# Altium schematic sheet ECO_Main_boardV1.0.SchDoc
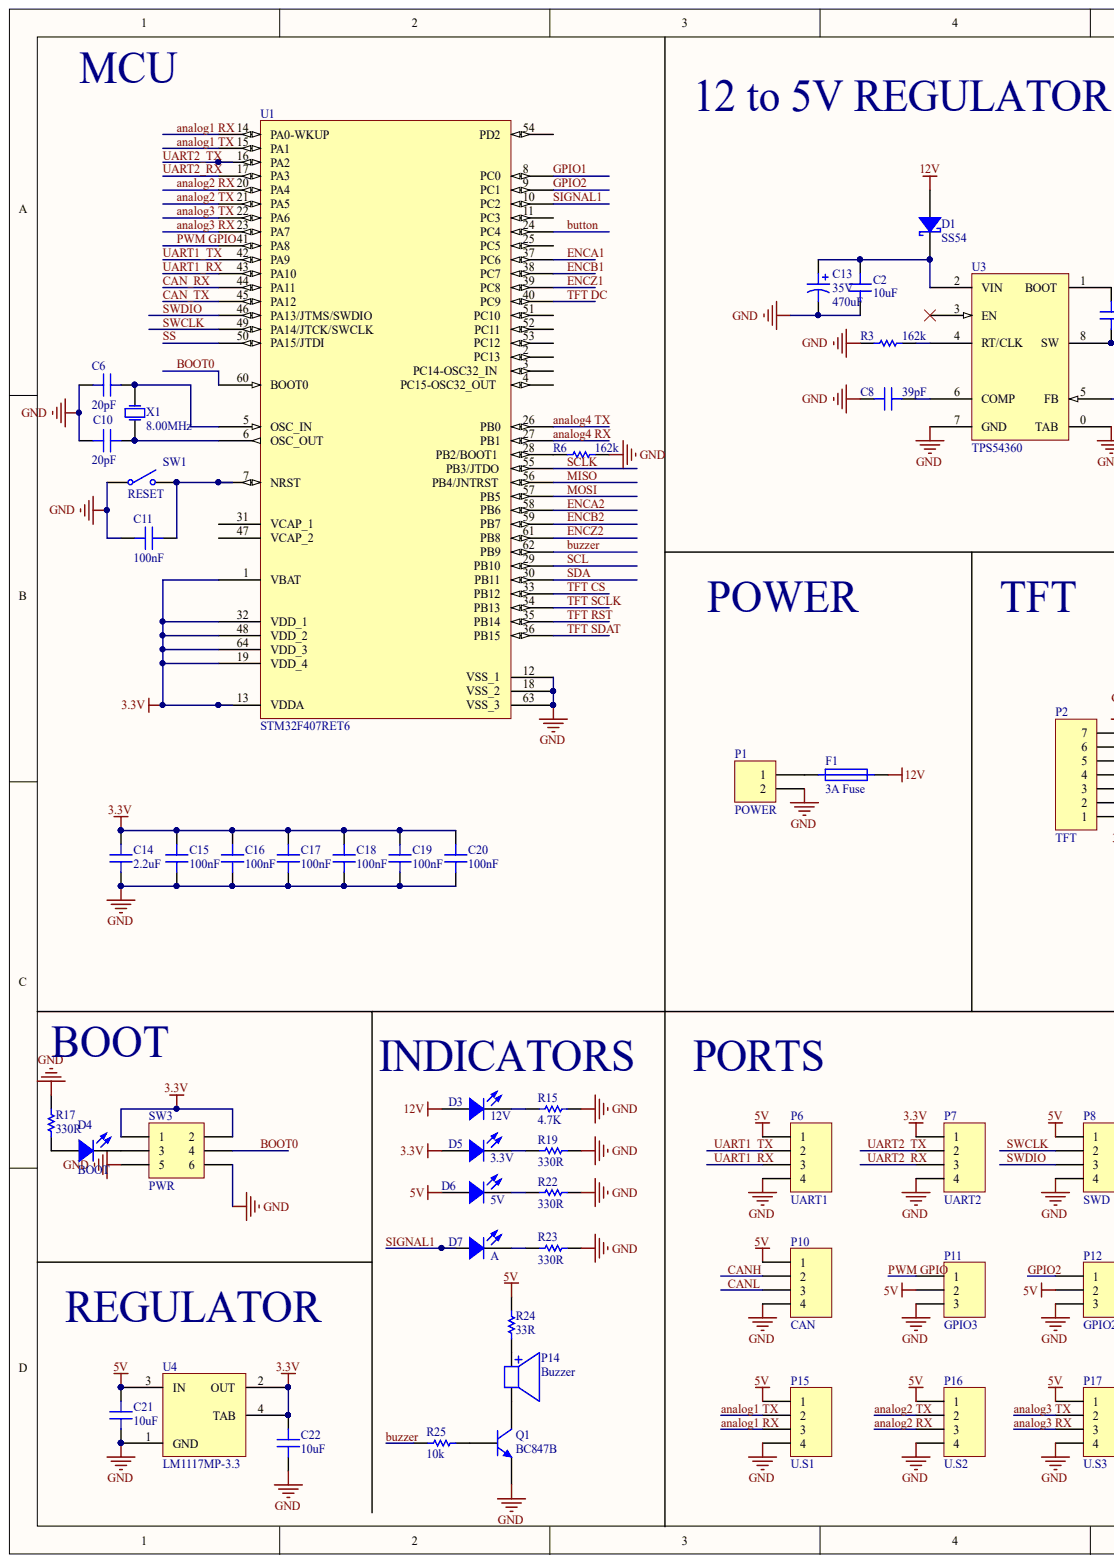
[diagram p0: ECO_Main_boardV1.0.SchDoc, left]
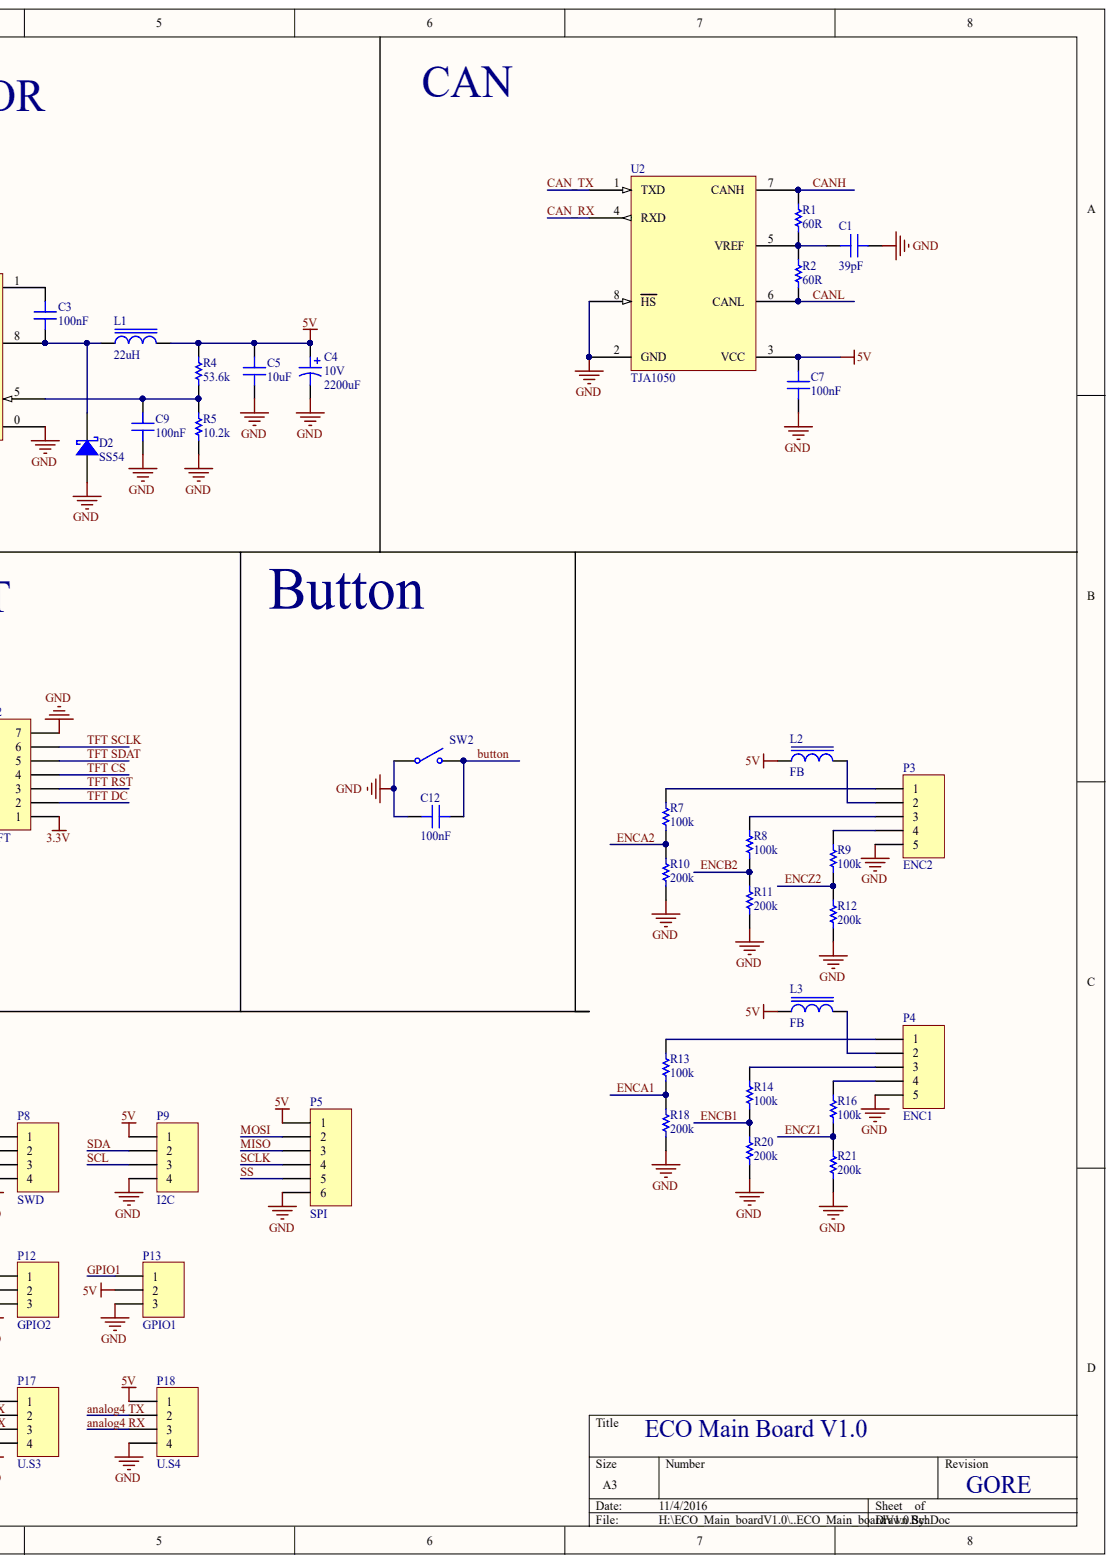
[diagram p1: ECO_Main_boardV1.0.SchDoc, right]

## Parts
C1  39pF  [CC2012-0805]
C2  10uF  [CC2012-0805]
C3  100nF  [CC2012-0805]
C4  2200uF  Voltage: 10V  [SV-G]
C5  10uF  [CC2012-0805]
C6  20pF  [CC2012-0805]
C7  100nF  [CC2012-0805]
C8  39pF  [CC2012-0805]
C9  100nF  [CC2012-0805]
C10  20pF  [CC2012-0805]
C11  100nF  [CC2012-0805]
C12  100nF  [CC2012-0805]
C13  470uF  Voltage: 35V  [CAP10x12]
C14  2.2uF  [CC2012-0805]
C15  100nF  [CC2012-0805]
C16  100nF  [CC2012-0805]
C17  100nF  [CC2012-0805]
C18  100nF  [CC2012-0805]
C19  100nF  [CC2012-0805]
C20  100nF  [CC2012-0805]
C21  10uF  [CC2012-0805]
C22  10uF  [CC2012-0805]
D1  SS54  [SMA]
D2  SS54  [SMA]
D3  12V  [D0805]
D4  BOOT  [D0805]
D5  3.3V  [D0805]
D6  5V  [D0805]
D7  A  [D0805]
F1  3A Fuse  [FUSE SOCKET]
L1  22uH  [CDRH74]
L2  FB  [CL3225-1210]
L3  FB  [CL3225-1210]
P1  POWER  [T-CONNECTOR(M)]
P2  TFT  [HDR1X7]
P3  ENC2  [lockedHDR-5]
P4  ENC1  [lockedHDR-5]
P5  SPI  [HDR1X6]
P6  UART1  [LOCKEDHDR-4]
P7  UART2  [LOCKEDHDR-4]
P8  SWD  [LOCKEDHDR-4]
P9  I2C  [LOCKEDHDR-4]
P10  CAN  [LOCKEDHDR-4]
P11  GPIO3  [LOCKEDHDR-3]
P12  GPIO2  [LOCKEDHDR-3]
P13  GPIO1  [LOCKEDHDR-3]
P14  Buzzer  [BUZ-9.0]
P15  U.S1  [LOCKEDHDR-4]
P16  U.S2  [LOCKEDHDR-4]
P17  U.S3  [LOCKEDHDR-4]
P18  U.S4  [LOCKEDHDR-4]
Q1  BC847B  [SOT-23]
R1  60R  [CR2012-0805]
R2  60R  [CR2012-0805]
R3  162k  [CR2012-0805]
R4  53.6k  [CR2012-0805]
R5  10.2k  [CR2012-0805]
R6  162k  [CR2012-0805]
R7  100k  [CR2012-0805]
R8  100k  [CR2012-0805]
R9  100k  [CR2012-0805]
R10  200k  [CR2012-0805]
R11  200k  [CR2012-0805]
R12  200k  [CR2012-0805]
R13  100k  [CR2012-0805]
R14  100k  [CR2012-0805]
R15  4.7K  [CR2012-0805]
R16  100k  [CR2012-0805]
R17  330R  [CR2012-0805]
R18  200k  [CR2012-0805]
R19  330R  [CR2012-0805]
R20  200k  [CR2012-0805]
R21  200k  [CR2012-0805]
R22  330R  [CR2012-0805]
R23  330R  [CR2012-0805]
R24  33R  [CR2012-0805]
R25  10k  [CR2012-0805]
SW1  RESET  [PUSH SWITCH]
SW2  (no value)  [PUSH SWITCH]
SW3  PWR  [POWER SWITCH]
U1  STM32F407RET6  [LQFP64_N]
U2  TJA1050  [SOP-8]
U3  TPS54360  [PDSO-8]
U4  LM1117MP-3.3  [MP04A_N]
X1  8.00MHz  [BCY-W2/E4.7]

## Nets
3.3V: C14.1 C15.2 C16.2 C17.2 C18.2 C19.2 C20.2 C22.2 D5.1 P2.1 P7.1 SW3.1 SW3.2 U1.1(VBAT) U1.13(VDDA) U1.19(VDD_4) U1.32(VDD_1) U1.48(VDD_2) U1.64(VDD_3) U4.2(OUT) U4.4(TAB)
5V: C4.1 C5.2 C7.2 C21.2 D6.1 L1.2 L2.1 L3.1 P5.1 P6.1 P8.1 P9.1 P10.1 P11.2 P12.2 P13.2 P15.1 P16.1 P17.1 P18.1 R4.2 R24.2 U2.3(VCC) U4.3(IN)
12V: D1.1 D3.1 F1.2
GND: C1.1 C2.1 C4.2 C5.1 C6.2 C7.1 C8.1 C9.1 C10.2 C11.2 C12.2 C13.2 C14.2 C15.1 C16.1 C17.1 C18.1 C19.1 C20.1 C21.1 C22.1 D2.1 P1.2 P2.7 P3.5 P4.5 P5.6 P6.4 P7.4 P8.4 P9.4 P10.4 P11.3 P12.3 P13.3 P15.4 P16.4 P17.4 P18.4 Q1.2 R3.1 R5.2 R6.2 R10.1 R11.1 R12.1 R15.2 R17.1 R18.1 R19.2 R20.1 R21.1 R22.2 R23.2 SW1.1 SW2.1 SW3.5 SW3.6 U1.12(VSS_1) U1.18(VSS_2) U1.63(VSS_3) U2.2(GND) U2.8(HS) U3.0(TAB) U3.7(GND) U4.1(GND)
ANALOG1 RX: P15.3 U1.14(PA0-WKUP)
ANALOG1 TX: P15.2 U1.15(PA1)
ANALOG2 RX: P16.3 U1.20(PA4)
ANALOG2 TX: P16.2 U1.21(PA5)
ANALOG3 RX: P17.3 U1.23(PA7)
ANALOG3 TX: P17.2 U1.22(PA6)
ANALOG4 RX: P18.3 U1.27(PB1)
ANALOG4 TX: P18.2 U1.26(PB0)
BOOT0: SW3.4 U1.60(BOOT0)
BUTTON: C12.1 SW2.2 U1.24(PC4)
BUZZER: R25.1 U1.62(PB9)
CAN_RX: U1.44(PA11) U2.4(RXD)
CAN_TX: U1.45(PA12) U2.1(TXD)
CANH: P10.2 R1.2 U2.7(CANH)
CANL: P10.3 R2.1 U2.6(CANL)
ENCA1: R13.1 R18.2 U1.37(PC6)
ENCA2: R7.1 R10.2 U1.58(PB6)
ENCB1: R14.1 R20.2 U1.38(PC7)
ENCB2: R8.1 R11.2 U1.59(PB7)
ENCZ1: R16.1 R21.2 U1.39(PC8)
ENCZ2: R9.1 R12.2 U1.61(PB8)
GPIO1: P13.1 U1.8(PC0)
GPIO2: P12.1 U1.9(PC1)
MISO: P5.3 U1.56(PB4/JNTRST)
MOSI: P5.2 U1.57(PB5)
PWM GPIO: P11.1 U1.41(PA8)
SCL: P9.3 U1.29(PB10)
SCLK: P5.4 U1.55(PB3/JTDO)
SDA: P9.2 U1.30(PB11)
SIGNAL1: D7.1 U1.10(PC2)
SS: P5.5 U1.50(PA15/JTDI)
SWCLK: P8.2 U1.49(PA14/JTCK/SWCLK)
SWDIO: P8.3 U1.46(PA13/JTMS/SWDIO)
TFT CS: P2.4 U1.33(PB12)
TFT DC: P2.2 U1.40(PC9)
TFT RST: P2.3 U1.35(PB14)
TFT SCLK: P2.6 U1.34(PB13)
TFT SDAT: P2.5 U1.36(PB15)
UART1_RX: P6.3 U1.43(PA10)
UART1_TX: P6.2 U1.42(PA9)
UART2_RX: P7.3 U1.17(PA3)
UART2_TX: P7.2 U1.16(PA2)
NetC1_2: C1.2 R1.1 R2.2 U2.5(VREF)
NetC2_2: C2.2 C13.1 D1.2 U3.2(VIN)
NetC3_1: C3.1 D2.2 L1.1 U3.8(SW)
NetC3_2: C3.2 U3.1(BOOT)
NetC6_1: C6.1 U1.5(OSC_IN) X1.2
NetC8_2: C8.2 U3.6(COMP)
NetC9_2: C9.2 R4.1 R5.1 U3.5(FB)
NetC10_1: C10.1 U1.6(OSC_OUT) X1.1
NetC11_1: C11.1 SW1.2 U1.7(NRST)
NetD3_2: D3.2 R15.1
NetD4_1: D4.1 R17.2
NetD4_2: D4.2 SW3.3
NetD5_2: D5.2 R19.1
NetD6_2: D6.2 R22.1
NetD7_2: D7.2 R23.1
NetF1_1: F1.1 P1.1
NetL2_2: L2.2 P3.2
NetL3_2: L3.2 P4.2
NetP3_1: P3.1 R7.2
NetP3_3: P3.3 R8.2
NetP3_4: P3.4 R9.2
NetP4_1: P4.1 R13.2
NetP4_3: P4.3 R14.2
NetP4_4: P4.4 R16.2
NetP14_1: P14.1 R24.1
NetP14_2: P14.2 Q1.3
NetQ1_1: Q1.1 R25.2
NetR3_2: R3.2 U3.4(RT/CLK)
NetR6_1: R6.1 U1.28(PB2/BOOT1)

Not connected: U1 2(PC13) 3(PC14-OSC32_IN) 4(PC15-OSC32_OUT) 11(PC3) 25(PC5) 31(VCAP_1) 47(VCAP_2) 51(PC10) 52(PC11) 53(PC12) 54(PD2); U3 3(EN)

## Library data of the parts
C1-C3 C5-C12 C14-C22 = Cap Semi: Capacitor (Semiconductor SIM Model); Component Kind: Standard; LatestRevisionDate: 17-Jul-2002; LatestRevisionNote: Re-released for DXP Platform.; Library Name: Robocon_RCL.IntLib; PackageReference: C1206; Published: 8-Jun-2000; Signal Integrity: Cap Semi; Publisher: Altium Limited
C4 = Cap Pol SMD: Polarized Capacitor (Axial); Component Kind: Standard; LatestRevisionDate: 17-Jul-2002; LatestRevisionNote: Re-released for DXP Platform.; Library Name: Robocon_RCL.IntLib; Published: 8-Jun-2000; Publisher: Altium Limited
C13 = Cap Pol DIP: Polarized Capacitor (Radial); Component Kind: Standard; LatestRevisionDate: 17-Jul-2002; LatestRevisionNote: Re-released for DXP Platform.; Library Name: Robocon_RCL.IntLib; Published: 8-Jun-2000; Publisher: Altium Limited
D1 D2 = D Schottky: Schottky Diode; Code - JEDEC: DO-214-AA; Component Kind: Standard; Library Name: Robocon_BJT.IntLib; Published: 8-Jun-2000; Revision: July-2002: Re-released for DXP Platform.; Publisher: Altium Limited
D3-D7 = LED: Typical RED GaAs LED; Component Kind: Standard; LatestRevisionDate: 08-Jul-2005; LatestRevisionNote: Mech Layer 1 removed.; Library Name: Robocon_BJT.IntLib; PackageReference: LED-1; Published: 8-Jun-2000; Publisher: Altium Limited
F1 = Fuse: Component Kind: Standard; Description: Fuse; Library Name: Robocon_RCL.IntLib; Published: 8-Jun-2000; Revision: July-2002: Re-released for DXP Platform.; Publisher: Altium Limited
L1-L3 = Inductor: Value: 10uH; Component Kind: Standard; Description: Inductor; Library Name: Robocon_RCL.IntLib; Published: 8-Jun-2000; Revision: July-2002: Re-released for DXP Platform.; Publisher: Altium Limited
P1 = Header 2: Header, 2-Pin; Component Kind: Standard; Library Name: Robocn_connectors.IntLib; Revision: 17-Jul-2002: Re-released for DXP Platform.; Signal Integrity: Connector; Publisher: Altium Limited
P2 = Header 7: Header, 6-Pin; Component Kind: Standard; LatestRevisionDate: 17-Jul-2002; LatestRevisionNote: Re-released for DXP Platform.; Library Name: Robocn_connectors.IntLib; Publisher: Altium Limited
P3 P4 = Header 5: Header, 5-Pin; Component Kind: Standard; LatestRevisionDate: 17-Jul-2002; LatestRevisionNote: Re-released for DXP Platform.; Library Name: Robocn_connectors.IntLib; Signal Integrity: Connector; Publisher: Altium Limited
P5 = Header 6: Header, 6-Pin; Component Kind: Standard; LatestRevisionDate: 17-Jul-2002; LatestRevisionNote: Re-released for DXP Platform.; Library Name: Robocn_connectors.IntLib; Publisher: Altium Limited
P6-P10 P15-P18 = Header 4: Header, 4-Pin; Component Kind: Standard; Library Name: Robocn_connectors.IntLib; Revision: 17-Jul-2002: Re-released for DXP Platform.; Signal Integrity: Connector; Publisher: Altium Limited
P11-P13 = Header 3: Header, 3-Pin; Component Kind: Standard; Library Name: Robocn_connectors.IntLib; Revision: 17-Jul-2002: Re-released for DXP Platform.; Signal Integrity: Connector; Publisher: Altium Limited
Q1 = BC847B: NPN; Component Kind: Standard; Library Name: Robocon_BJT.IntLib
R1-R25 = Res Semi: Semiconductor Resistor; Component Kind: Standard; LatestRevisionDate: 17-Jul-2002; LatestRevisionNote: Re-released for DXP Platform.; Library Name: Robocon_RCL.IntLib; Published: 8-Jun-2000; Signal Integrity: Res Semi; Publisher: Altium Limited
SW1 SW2 = SW-SPST: Single-Pole, Single-Throw Switch; Component Kind: Standard; Library Name: Robocn_connectors.IntLib; Published: 24-Mar-1999; Revision: July-2002: Re-released for DXP Platform.; Publisher: Altium Limited
SW3 = Header 3X2: Header, 3-Pin, Dual row; Component Kind: Standard; Library Name: Robocn_connectors.IntLib; Revision: 17-Jul-2002: Re-released for DXP Platform.; Signal Integrity: Connector; Publisher: Altium Limited
U1 = STM32F407RET6: STM32 ARM-based 32-bit MCU with 64 Kbytes Flash, 100-pin LQFP, Industrial Temperature; Component Kind: Standard; ComponentLink1Description: Manufacturer Link; ComponentLink1URL: www.st.com/stm32; ComponentLink2Description: Datasheet; ComponentLink2URL: http://www.st.com/stonline/products/literature/ds/13587.pdf; DatasheetVersion: rev6, Mar-2008; Library Name: Robocon_IC.IntLib; PackageDescription: 100-Pin Low-Profile Quad Flat Pack, 14 x 14 mm Body, 0.5 mm Pitch (LQFP100); PackageReference: LQFP100; PackageVersion: Mar-2008; Published: 30-May-2008; Publisher: Altium Limited
U2 = TJA1050: 5V to 12V Charge Pump; Component Kind: Standard; Library Name: Robocon_IC.IntLib
U3 = TPS54360: 60 V, 3.5 A , Step Down DC-DC Converter with Eco-Mode; Component Kind: Standard; Library Name: Robocon_IC.IntLib
U4 = LM1117MP-3.3: 800mA Low-Dropout Linear Regulator; Manufacturer: National Semiconductor; CompType: LDO IC; PackageTopMarking: XYTT*N05A; Code_IPC: SOT223-4; Code_JEDEC: TO-261-AA; Component Kind: Standard; ComponentLink1Description: LM1117 Datasheet; ComponentLink1URL: http://www.national.com/ds/LM/LM1117.pdf; ComponentLink2Description: LM1117 Web Page; ComponentLink2URL: http://www.national.com/pf/LM/LM1117.html; ComponentLink3Description: MP04A Package Page; ComponentLink3URL: http://www.national.com/packaging/folders/mp04a.html; DatasheetVersion: Apr-2006; DigiKeyPartNumber: LM1117MP-3.3CT-ND; Library Name: Robocon_IC.IntLib; PackageDescription: Molded SOT-223, 6.50 x 3.56 x 1.6mm Body, 4 Lead, 2.3mm Pitch; PackageReference: MP04A; PackageVersion: revB, Apr-2003; PartNumber: LM1117MP-3.3; PinCount: 4; Published: 27-Nov-2008; RoHS: O; Publisher: Altium Limited
X1 = XTAL: Crystal Oscillator; Component Kind: Standard; Library Name: Robocon_RCL.IntLib; Published: 8-Jun-2000; Revision: July-2002: Re-released for DXP Platform.; Publisher: Altium Limited
P14 = Buzzer: Component Kind: Standard; Library Name: Robocon_RCL.IntLib
